# Altium schematic sheet KD_Subsystem.SchDoc
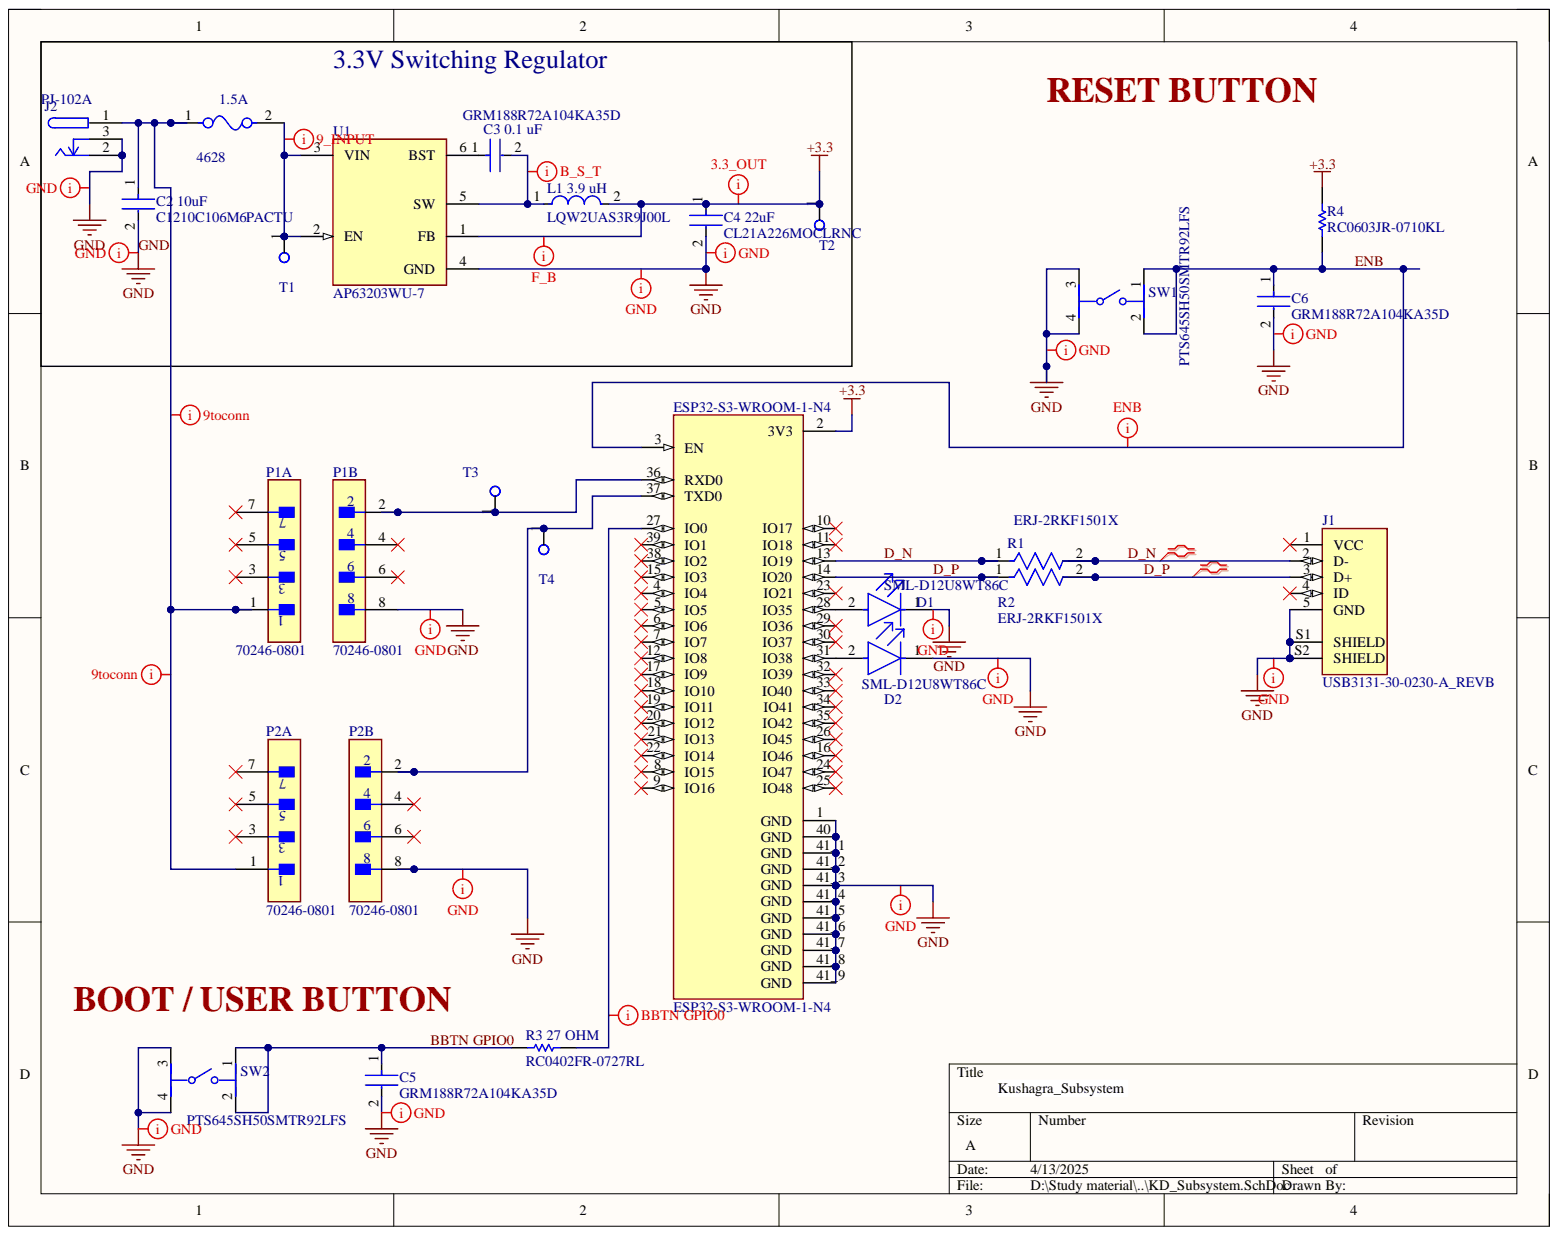
[diagram p0: KD_Subsystem.SchDoc]

## Parts
1.5A  4628  [4628-Footprint-1]
C2_10uF  C1210C106M6PACTU  Capacitance: 10uF  [FP-C1210C106M6PACTU-MFG]
C3_0.1_uF  GRM188R72A104KA35D  [FP-GRM188-0_1-e0_2_0_5-MFG]
C4_22uF  CL21A226MOCLRNC  Capacitance: 22uF  [FP-CL21-IPC_C]
C5  GRM188R72A104KA35D  [FP-GRM188-0_1-e0_2_0_5-MFG]
C6  GRM188R72A104KA35D  [FP-GRM188-0_1-e0_2_0_5-MFG]
D1  SML-D12U8WT86C  [FP-SML-D12-MFG]
D2  SML-D12U8WT86C  [FP-SML-D12-MFG]
ESP32-S3-WROOM-1-N4  ESP32-S3-WROOM-1-N4  [XCVR_ESP32-S3-WROOM-1-N4]
J1  USB3131-30-0230-A_REVB  [GCT_USB3131-30-0230-A_REVB]
J2  PJ-102A  [FP-PJ-102A-MFG]
L1_3.9_uH  LQW2UAS3R9J00L  [FP-LQW2UAS_00-MFG]
P1  70246-0801  [FP-0702460801-MFG]  (parts A,B)
P2  70246-0801  [FP-0702460801-MFG]  (parts A,B)
R1  ERJ-2RKF1501X  [FP-ERJ2RK-MFG]
R2  ERJ-2RKF1501X  [FP-ERJ2RK-MFG]
R3_27_OHM  RC0402FR-0727RL  [RESC1005X40X25LL05T10]
R4  RC0603JR-0710KL  [RESC1608X55X25ML10T15]
SW1  PTS645SH50SMTR92LFS  [FP-PTS645SH50SMTR92LFS-MFG]
SW2  PTS645SH50SMTR92LFS  [FP-PTS645SH50SMTR92LFS-MFG]
T1  Test point  [Test point-Footprint-1]  (value not drawn)
T2  Test point  [Test point-Footprint-1]  (value not drawn)
T3  Test point  [Test point-Footprint-1]  (value not drawn)
T4  Test point  [Test point-Footprint-1]  (value not drawn)
U1  AP63203WU-7  [FP-TSOT26-MFG]

## Nets
+3.3: C4_22uF.1 ESP32-S3-WROOM-1-N4.2(3V3) L1_3.9_uH.2 R4.2 T2.1 U1.1(FB)
BBTN GPIO0: C5.1 R3_27_OHM.1 SW2.1 SW2.2
D_N: ESP32-S3-WROOM-1-N4.13(IO19) J1.2(D-) R1.1 R1.2
D_P: ESP32-S3-WROOM-1-N4.14(IO20) J1.3(D+) R2.1 R2.2
ENB: C6.1 ESP32-S3-WROOM-1-N4.3(EN) R4.1 SW1.1 SW1.2
GND: C2_10uF.2 C4_22uF.2 C5.2 C6.2 D1.1 D2.1 ESP32-S3-WROOM-1-N4.1(GND) ESP32-S3-WROOM-1-N4.40(GND) ESP32-S3-WROOM-1-N4.41_1(GND) ESP32-S3-WROOM-1-N4.41_2(GND) ESP32-S3-WROOM-1-N4.41_3(GND) ESP32-S3-WROOM-1-N4.41_4(GND) ESP32-S3-WROOM-1-N4.41_5(GND) ESP32-S3-WROOM-1-N4.41_6(GND) ESP32-S3-WROOM-1-N4.41_7(GND) ESP32-S3-WROOM-1-N4.41_8(GND) ESP32-S3-WROOM-1-N4.41_9(GND) J1.5(GND) J1.S1(SHIELD) J1.S2(SHIELD) J2.2 J2.3 P1.8 P2.8 SW1.3 SW1.4 SW2.3 SW2.4 U1.4(GND)
Net1.5A_1: 1.5A.1 C2_10uF.1 J2.1 P1.1 P2.1
Net1.5A_2: 1.5A.2 T1.1 U1.2(EN) U1.3(VIN)
NetC3 0.1 uF_1: C3_0.1_uF.1 U1.6(BST)
NetC3 0.1 uF_2: C3_0.1_uF.2 L1_3.9_uH.1 U1.5(SW)
NetD1_2: D1.2 ESP32-S3-WROOM-1-N4.28(IO35)
NetD2_2: D2.2 ESP32-S3-WROOM-1-N4.31(IO38)
NetESP32-S3-WROOM-1-N4_27: ESP32-S3-WROOM-1-N4.27(IO0) R3_27_OHM.2
NetESP32-S3-WROOM-1-N4_36: ESP32-S3-WROOM-1-N4.36(RXD0) P1.2 T3.1
NetESP32-S3-WROOM-1-N4_37: ESP32-S3-WROOM-1-N4.37(TXD0) P2.2 T4.1

Not connected: ESP32-S3-WROOM-1-N4 4(IO4) 5(IO5) 6(IO6) 7(IO7) 8(IO15) 9(IO16) 10(IO17) 11(IO18) 12(IO8) 15(IO3) 16(IO46) 17(IO9) 18(IO10) 19(IO11) 20(IO12) 21(IO13) 22(IO14) 23(IO21) 24(IO47) 25(IO48) 26(IO45) 29(IO36) 30(IO37) 32(IO39) 33(IO40) 34(IO41) 35(IO42) 38(IO2) 39(IO1); J1 1(VCC) 4(ID); P1 3 4 5 6 7; P2 3 4 5 6 7

## Library data of the parts
1.5A = CMP-00029-1: Fuses/Accessories; Component Kind: Standard; Library Name: MacroChip
C2_10uF = CMP-1038-02235-2: CAP CER 10UF 35V X5R 1210; Dielectric Material: Ceramic; Dissipation Factor: 2.5%; Tolerance: 20%; Case Code (Imperial): 1210; Case Code (Metric): 3225; Case/Package: 1210; Component Kind: Standard; Construction: Flat; Depth: 2.5mm; Dielectric: X5R; Height: 1.9mm; Length: 3.2mm; Library Name: Altium Content Vault; Max Operating Temperature: 85°C; Min Operating Temperature: -55°C; Mount: Surface Mount; Package Quantity: 2000; Packaging: Tape and Reel; Radiation Hardening: No; REACH SVHC: No SVHC; Series: C; Termination: SMD/SMT; Thickness: 0.083inch; Voltage: 35V; Voltage Rating: 35V; Voltage Rating (DC): 35V; Width: 2.5mm
C3_0.1_uF C5 C6 = CMP-1035-02595-8: Chip Multilayer Ceramic Capacitors for General Purpose, 0603, 0.10uF, X7R, 15%, 10%, 100V; Capacitance: 100nF; Case Code (Imperial): 0603; Case Code (Metric): 1608; Case/Package: 0603; Component Kind: Standard; Depth: 0.8mm; Dielectric: X7R; Height: 0.8mm; Lead Free: Lead Free; Length: 1.6mm; Library Name: Altium Content Vault; Material: Ceramic; Max Operating Temperature: 125°C; Min Operating Temperature: -55°C; Mount: Surface Mount; Package Quantity: 1; Packaging: Tape and Reel; Radiation Hardening: No; REACH SVHC: No SVHC; Termination: SMD/SMT; Thickness: 0.035inch; Tolerance: 10%; Voltage: 100V; Voltage Rating: 100V; Voltage Rating (DC): 100V; Weight: 0.000222oz; Width: 0.8mm
C4_22uF = CMP-13271-002990-1: CL21 Series 0805 22uF 16V ±20% Tolerance X5R Multilayer Ceramic Chip Capacitor; Case Code (Imperial): 0805; Case Code (Metric): 2012; Case/Package: 0805; Component Kind: Standard; Length: 0.079inch; Library Name: Altium Content Vault; Max Operating Temperature: 85°C; Min Operating Temperature: -55°C; Mount: Surface Mount; Packaging: Tape and Reel; Thickness: 0.037inch; Tolerance: 20%; Voltage Rating: 16V; Width: 0.049inch
D1 D2 = CMP-08952-000014-1: LED RED 1608 SMD; Manufacturer: Rohm; Automotive Grade: Grade 3; Forward Current: 20mA; Forward Voltage: 2.2V; Led Orientation: Top View; Luminous Intensity: 63mcd; Power Dissipation: 54mW; Reverse Voltage: 5V; Wavelength: 620nm; Automotive: Yes; Category: Diode; Colour: Red; Component Kind: Standard; ComponentLink2Description: Datasheet; ComponentLink2URL: https://fscdn.rohm.com/en/products/databook/datasheet/opto/led/chip_mono/sml-d12x8(c)-e.pdf; Device Class L1: Optoelectronics; Device Class L2: LEDs; Device Class L3: unset; Digikey Description: LED RED 1608 SMD; Height: 0.65mm; Lead Free: Yes; Library Name: Altium Content Vault; Manufacturer Part Number: SML-D12U8WT86C; Mouser Description: LED Uni-Color Red Automotive 2-Pin Chip 0603(1608Metric) T/R; Octopart: https://octopart.com/sml-d12u8wt86c-rohm-77740214; Package: SML-D12; RoHS: TRUE; Temperature: -40°C to +85°C; Temperature Range High: +85°C; Temperature Range Low: -40°C
ESP32-S3-WROOM-1-N4 = CMP-002-000000-1: MF: {'manufacturer': u'Espressif Systems'}; Availability: Unavailable; Component Kind: Standard; Component Type: Standard; Design Item ID: ESP32-S3-WROOM-1-N4; MP: ESP32-S3-WROOM-1-N4; Package: None; Pin Count: 49; Price: None; Source: ESP32-S3-WROOM-1-N4.SchLib; Library Name: MacroChip
J1 = CMP-008-000000-1: USB - micro B USB 2.0 Receptacle Connector 5 Position Through Hole; Availability: Unavailable; Component Kind: Standard; Component Type: Standard; Design Item ID: USB3131-30-0230-A_REVB; MP: USB3131-30-0230-A_REVB; Package: None; Pin Count: 7; Price: None; Source: USB3131-30-0230-A_REVB.SchLib; Library Name: MacroChip
J2 = CMP-00031-1: 2.0 x 6.5 mm, 2.5 A, Horizontal, Through Hole, Tapered Pins, Dc Power Jack Connector; Component Kind: Standard; Library Name: MacroChip
L1_3.9_uH = CMP-06042-014604-1: Wire Wound RF Inductor 3900nH ±5% 260mA 3.6Ω 1008 (2520); Core Material: Air; DC Resistance (DCR): 3.6R; Inductance: 3.9uH; Q Factor: 20; Self Resonant Frequency: 70MHz; Shielding: Unshielded; Test Frequency: 7.9MHz; Case Code (Imperial): 1008; Case Code (Metric): 2520; Case/Package: 1008; Component Kind: Standard; Current Rating: 260mA; Depth: 2.65mm; Height: 1.83mm; Height - Seated (Max): 0.08inch; Inductance Tolerance: 5%; Length: 0.103inch; Library Name: Altium Content Vault; Max DC Current: 260mA; Max Operating Temperature: 125°C; Min Operating Temperature: -55°C; Mount: Surface Mount; Package Quantity: 2000; Packaging: Tape and Reel; Radiation Hardening: No; REACH SVHC: No SVHC; Resistor Type: Wirewound; Termination: SMD/SMT; Tolerance: 5%; Weight: 0.001376oz; Width: 0.096inch
P1 P2 = CMP-00034-1: Board Connector, 8 Contact(s), 2 Row(s), Male, Straight, 0.1 inch Pitch, Solder Terminal, Guide Slot, Black Insulator, Receptacle; Manufacturer Series: 70246; Additional Feature: SHROUDED; Body Breadth: 0.35inch; Body Depth: 0.365inch; Body Length: 0.7inch; Contact Pattern: RECTANGULAR; Contact Style: SQ PIN-SKT; Dielectric Withstanding Voltage (AC): 900V; DIN Conformance: No; Insulation Resistance: 12GR; Mounting Option 2: LOCKING; Number Of Connectors: 1; Number of Contacts: 8; Number Of PCB Rows: 2; Option: General Purpose; PCB Contact Row Spacing: 2.54mm; Plating Thickness: 15uin; Polarization Key: POLARIZED HOUSING; Rated Current (Signal): 2.5A; Reference Standard: UL; Reliability: COMMERCIAL; Body/Shell Style: RECEPTACLE; Component Kind: Standard; Connector Type: BOARD CONNECTOR; Contact Finish - Mating: GOLD (15) OVER NICKEL; Contact Finish - Termination: Tin (Sn) - with Nickel (Ni) barrier; Contact Gender: Male; Contact Material: Copper Alloy; Filter Feature: No; Flammability Rating: UL94 V-0; IEC Conformance: No; Insulation Color: Black; Insulation Material: Glass; JESD-609 Code: e3; Mating Contact Pitch: 0.1inch; Mating Contact Row Spacing: 0.1inch; Max Operating Temperature: 120°C; MIL Conformance: No; Min Operating Temperature: -55°C; Mixed Contacts: No; Mounting Option 1: GUIDE SLOT; Mounting Style: STRAIGHT; Mounting Technology: Board; Number of Rows Loaded: 2; PCB Contact Pattern: RECTANGULAR; Reach SVHC Compliant: Yes; RoHS Compliant: Yes; Terminal Length: 0.135inch; Terminal Pitch: 2.54mm; Termination: Solder; Library Name: MacroChip
R1 R2 = CMP-2002-00693-2: RES SMD 1.5K OHM 1% 1/10W 0402; Max Power Dissipation: 100mW; Resistance: 1.5kR; Temperature Coefficient: 100ppm/°C; Voltage Rating: 50V; Case Code (Imperial): 0402; Case Code (Metric): 1005; Case/Package: 0402; Component Kind: Standard; Construction: Rectangular; Contact Plating: Tin; Depth: 0.5mm; Features: Automotive AEC-Q200; Height: 0.35mm; Lead Free: Lead Free; Length: 1mm; Library Name: Altium Content Vault; Max Operating Temperature: 155°C; Military Standard: Not; Min Operating Temperature: -55°C; Mount: Surface Mount; Number of Pins: 2; Number of Terminations: 2; Package Quantity: 1; Packaging: Tape and Reel; Power Rating: 100mW; Radiation Hardening: No; REACH SVHC: No SVHC; Resistance Tolerance: 1%; Resistor Type: Thick Film; RoHS Compliant: Yes; Series: ERJ; Size Code: 0402; Technology: THICK FILM; Termination: SMD/SMT; Tolerance: 1%; Voltage Rating (DC): 50V; Width: 0.02inch
R3_27_OHM = CMP-2002-07055-1: Manufacturer: Yageo / Phycomp; Component Kind: Standard; Library Name: Altium Content Vault; Part Number: RC0402FR-0727RL
R4 = CMP-2000-05022-1: Resistor, 10 KOhm, +/- 5%, 100 mW, -55 to 155 degC, 0603 (1608 Metric), RoHS, Tape and Reel; Manufacturer: Yageo; Power Rating: 100mW; Resistance: 10kOhms; Temperature Coefficient: 100PPM/C; Voltage Rating: 50V; Case Code - in: 0603; Case Code - mm: 1608; Component Kind: Standard; Datasheet URL: http://www.farnell.com/datasheets/443971.pdf; Datasheet Version: Rev. 4, 04/2009; Library Name: Altium Content Vault; Manufacturer URL: http://www.yageo.com/; Operating Temperature Range: -55Cto+155C; Package Description: 2-Pin Surface Mount Device, Body 1.6 x 0.8 mm; Package Reference: 603; Packaging: Reel; Product: ThickFilmResistorsGeneralPurpose; Series: RC; Termination Style: SMD/SMT; Tolerance: 5%
SW1 SW2 = CMP-10040-000028-1: SWITCH TACTILE SPST-NO 0.05A 12V; Actuator Material: Nylon; Actuator Type: Standard; Current Rating: 50mA; Illumination Type: Non-Illuminated; Mechanical Life: 100000Cycles; Operating Force: 200gf; Switch Function: Off-Mom; Throw Configuration: SPST; Case/Package: SMD/SMT; Color: Black; Component Kind: Standard; Contact Material: Bronze; Contact Plating: Silver; Depth: 9mm; Lead Free: Lead Free; Length: 6mm; Library Name: Altium Content Vault; Max Current Rating: 50mA; Max Operating Temperature: 60°C; Max Voltage Rating (DC): 12V; Min Operating Temperature: -20°C; Mount: Surface Mount; Orientation: Straight; Package Quantity: 1000; Packaging: Tape and Reel; REACH SVHC: No SVHC; Termination: Gull Wing; Voltage Rating (DC): 12V
T1-T4 = CMP-00030-4: tht test point; Component Kind: Standard; Library Name: MacroChip
U1 = CMP-12757-000006-1: IC REG BUCK 3.3V 2A TSOT26; Manufacturer: Diodes Inc.; Max Junction Temp: +160°C; Max Supply Voltage: 32V; Min Supply Voltage: 3.8V; Nominal Supply Current: 22uA; Number Of Outputs: 1; Output Type: Fixed; Standoff Height: 0.01mm; Switching Frequency: 1.1MHz; Ambient Temperature Range High: +85°C; Ambient Temperature Range Low: -40°C; Automotive: No; Category: IC; Component Kind: Standard; ComponentLink2Description: Datasheet; ComponentLink2URL: https://www.diodes.com/assets/Datasheets/AP63200-AP63201-AP63203-AP63205.pdf; Device Class L1: Integrated Circuits (ICs); Device Class L2: Power Management ICs; Device Class L3: Voltage Regulators - Switching; Digikey Description: IC REG BUCK 3.3V 2A TSOT26; Footprint Url: https://www.diodes.com/assets/Package-Files/TSOT26.pdf; Height: 1mm; Lead Free: Yes; Library Name: Altium Content Vault; Manufacturer Part Number: AP63203WU-7; Mouser Description: AP63203 Series 3.3 V 2 A SMT Fixed Low IQ Synchronous Buck - TSOT-26; Octopart: https://octopart.com/ap63203wu-7-diodes+inc.-98099550; Output Current: 2A; Output Voltage: 3.3V; Package: TSOT26; RoHS: TRUE; Switching Topology: Buck; Temperature: to +160°C; Temperature Range High: +160°C
